# Altium schematic sheet SCH-CAPE-Nucleo_Interface_V1R0.SchDoc(SCH-CAPE-Nucleo_Interface_V1R0) (continued)

## Library data of the parts
C1-C17 C19-C34 = SMT Capacitor: Ceramic Capacitor, =Value, =Tolerance, =VoltageRating, =Package; Component Kind: Standard; Library Name: PEF.IntLib
C18 = SMT Capacitor: Ceramic Capacitor, =Value, =Tolerance, =VoltageRating, =Package; Value: 0.1 µF; Component Kind: Standard; Library Name: PEF.IntLib
D1 = CMP-1055-00395-1: Schottky Power Rectifier, 2-Pin SMC, Tape and Reel; Manufacturer: On Semiconductor; IFSM Max (A): 80; Configuration: Single; RoHS: FALSE; PartNumber: MBRS340T3; Packing: Tape and Reel; PackageVersion: Rev. E, 08/2005; PackageReference: SMC-2-403-03; PackageDescription: 2-Pin Small Molded Package, J-Bend Lead, Body 5.845 x 6.855 mm; Mounting Technology: Surface Mount; Library Name: Altium Content Vault; DatasheetVersion: Rev. 11; ComponentLink3URL: http://www.onsemi.com/pub_link/Collateral/403-03.PDF; ComponentLink3Description: Package Specification; ComponentLink2URL: http://www.onsemi.cn/pub_link/Collateral/MBRS340T3-D.PDF; ComponentLink2Description: Datasheet; ComponentLink1URL: http://www.onsemi.com/; ComponentLink1Description: Manufacturer URL; Component Kind: Standard
DBG1 = Header 16X2H: Header, 16-Pin, Dual row, Right Angle; Signal Integrity: Connector; Library Name: Miscellaneous Connectors.IntLib; LatestRevisionNote: Re-released for DXP Platform.; LatestRevisionDate: 17-Jul-2002; Component Kind: Standard; Publisher: Altium Limited
DS1-DS7 TNC_EGY TNC_PTT UHF VHF = CMP-2000-05792-1: Chip LED Lamp, Green, 5 V, 30 mA, -40 to 85 degC, 2-Pin SMD, RoHS, Tape and Reel; Manufacturer: Kingbright; Wavelength - Peak: 574nm; Wavelength - Dominant: 570nm; Voltage - Forward (Vf) (Typ): 2.1V; Viewing Angle: 50°; Other Names: 754-1152-2; Online Catalog: 3.2mmx1.6mmRoundwithDomedTop1206PackageLEDs; Millicandela Rating: 300mcd; Lens Type: Clear; Lens Style/Size: RoundwithDomedTop,1.6mm; Current - Test: 20mA; Catalog Drawings: APTD3216SeriesTop    APTD3216SeriesSide; 3D Model: APTD3216x.sldprt    APTD3216x.pro    APTD3216x.igs    APTD3216x.stp; Standard Package: 2,000; Size / Dimension: 3.20mmLx1.60mmW; Part Number: APTD3216CGCK; Packaging: Tape&Reel(TR); Package Reference: APTD3216CGCK; Package Description: 2-Pin Surface Mount Device, Body 3.2 x 1.6 mm; Package / Case: 1206(3216Metric); Mounting Type: SurfaceMount; Mounting Technology: SurfaceMount; Manufacturer URL: http://www.kingbright.com/; Library Name: Altium Content Vault; Height: 1.80mm; Family: LEDIndication-Discrete; Datasheet Version: Rev. V.17B, 04/2015; Datasheet URL: https://www.kingbrightusa.com/images/catalog/spec/APTD3216CGCK.pdf; Component Kind: Standard; Color: Green; Category: Optoelectronics
FB1 = CMP-0686-00732-2: Chip Ferrite Bead for Power Lines, 120 Ohm, 3500 mA, -55 to 125 degC, 3.2 x 1.6 x 1.3 mm SMD, Tape and Reel; Manufacturer: Murata; Rated Current: 3500 mA; Impedance (at 100 MHz): 120 Ohm +/- 25%; DC Resistance: 0.02 Ohm Max.; Part Number: BLM31PG121SN1L; Packing: Tape and Reel; PackageReference: BLM31-2; PackageDescription: 2-Pin Chip, Body 3.2 x 1.6 mm; Operating Temperature Range: -55 to 125 degC; Mounting Technology: Surface Mount; Library Name: Altium Content Vault; DatasheetVersion: 08/2013; ComponentLink3URL: http://www.murata.com/products/catalog/pdf/c31e.pdf; ComponentLink3Description: Package Specification; ComponentLink2URL: http://www.murata.com/products/catalog/pdf/c31e.pdf; ComponentLink2Description: Datasheet; ComponentLink1URL: http://www.murata.com/; ComponentLink1Description: Manufacturer URL; Component Kind: Standard
N5 = Header 10: Header, 10-Pin; Signal Integrity: Connector; Library Name: Miscellaneous Connectors.IntLib; LatestRevisionNote: Re-released for DXP Platform.; LatestRevisionDate: 17-Jul-2002; Component Kind: Standard; Publisher: Altium Limited
N6 N9 = Header 8: Header, 8-Pin; Signal Integrity: Connector; Library Name: Miscellaneous Connectors.IntLib; LatestRevisionNote: Re-released for DXP Platform.; LatestRevisionDate: 17-Jul-2002; Component Kind: Standard; Publisher: Altium Limited
N8 = Header 6: Header, 6-Pin; Signal Integrity: Connector; Library Name: Miscellaneous Connectors.IntLib; LatestRevisionNote: Re-released for DXP Platform.; LatestRevisionDate: 17-Jul-2002; Component Kind: Standard; Publisher: Altium Limited
PA_Power TNCi TNCo UHFi UHFo Vbus Vbus_f VHFi VHFo = CMP-1672-00008-1: Test Point, 1 Position SMD, RoHS, Tape and Reel; Manufacturer: Keystone Electronics; RoHSCompliant: True; Pins: 1; Part Number: 5019; Packaging: Tape and Reel; PackageReference: 5019; Package Quantity: 1000; PackageDescription: 1-Pin Surface Mount Device, Body 3.81 x 2.03 mm; Mounting Technology: Surface Mount; Mount: Surface Mount; Library Name: Altium Content Vault; DatasheetVersion: 03/2014; Contact Plating: 9; ComponentLink2URL: http://www.keyelco.com/product-pdf.cfm?p=1357; ComponentLink2Description: Datasheet; ComponentLink1URL: http://www.keyelco.com/; ComponentLink1Description: Manufacturer URL; Component Kind: Standard
R1 R2 R5-R15 R19-R27 R29-R51 = SMT Resistor: Generic SMT Resistor; Component Kind: Standard; Library Name: PEF.IntLib
R3 = SMT Resistor: Generic SMT Resistor; Value: 56; Component Kind: Standard; Library Name: PEF.IntLib
R4 = SMT Resistor: Generic SMT Resistor; Value: 160; Component Kind: Standard; Library Name: PEF.IntLib
R16 R17 = SMT Resistor: Generic SMT Resistor; Value: 330; Component Kind: Standard; Library Name: PEF.IntLib
R18 = SMT Resistor: Generic SMT Resistor; Value: 330; Tolerance: {Tol}; Component Kind: Standard; Library Name: PEF.IntLib
R28 = SMT Resistor: Generic SMT Resistor; Value: 1 KΩ; Component Kind: Standard; Library Name: PEF.IntLib
TNC_PROG = Header 5: Header, 5-Pin; Signal Integrity: Connector; Library Name: Miscellaneous Connectors.IntLib; LatestRevisionNote: Re-released for DXP Platform.; LatestRevisionDate: 17-Jul-2002; Component Kind: Standard; Publisher: Altium Limited
U1 = CMP-0859-00540-3: Dual Bus Buffer Gate with 3-State Outputs, DCT0008A, LARGE T&R; Manufacturer: Texas Instruments; Voltage(Nom)(V): 1.8,2.5,3.3,5; VCC(Min)(V): 1.65; VCC(Max)(V): 5.5; tpd @ Nom Voltage(Max)(ns): 9.1,4.8,4.3,3.7; Technology Family: LVC; Sub-Family: Non-Inverting Buffer/Driver; Schmitt Trigger: No; Rating: Catalog; Output Type: CMOS; Output Drive (IOL/IOH)(Max)(mA): 32/-32; Logic: True; Input Type: CMOS/TTL; ICC @ Nom Voltage(Max)(mA): 0.01; F @ Nom Voltage(Max)(Mhz): 150; Bits(#): 2; 3-State Output: Yes; PartId: 1; PackageReference: DCT0008A; Operating Temperature Range(C): -40 to 125; Library Name: Altium Content Vault; Generic Part Number: SN74LVC2G125; DatasheetVersion: SCES204O; Component Kind: Standard
U2 = CMP-0859-00210-3: Dual Inverter, DBV0006A, SMALL T&R; Manufacturer: Texas Instruments; Voltage(Nom)(V): 1.8,2.5,3.3,5; VCC(Min)(V): 1.65; VCC(Max)(V): 5.5; tpd @ Nom Voltage(Max)(ns): 8,4.9,4.6,3.7; Technology Family: LVC; Sub-Family: Inverting Buffer/Driver; Schmitt Trigger: No; Rating: Catalog; Output Type: CMOS; Output Drive (IOL/IOH)(Max)(mA): 32/-32; Logic: Inverting; Input Type: CMOS/TTL; ICC @ Nom Voltage(Max)(mA): 0.01; F @ Nom Voltage(Max)(Mhz): 150; Bits(#): 2; PartId: 1; PackageReference: DBV0006A; Operating Temperature Range(C): -40 to 125; Library Name: Altium Content Vault; Generic Part Number: SN74LVC2G04; DatasheetVersion: SCES195M; Component Kind: Standard; 3-State Output: No
U3 = CMP-0314-00167-2: Remote 8-Bit I2C and SMBus I/O Expander with Interrupt Output and Configuration Registers, 8 Outputs, 2.3 to 5.5 V, -40 to 85 degC, 16-pin SOIC (DW), Green (RoHS & no Sb/Br); Manufacturer: Texas Instruments; VCC(Min)(V): 2.3; VCC(Max)(V): 5.5; Technology Family: PCA; SubFamily: I2C IO Expander; Push-Pull I/O Type: Yes; No. of Outputs: 8; Max Frequency(kHz): 400; I2C Address: 0100 xxx; Vcc range(V): 2.3 to 5.5; PartNumber: PCA9554DWR; PackageVersion: revG, Jan-2011; PackageReference: DW0016A; PackageDescription: 16-Pin Small Outline Integrated Circuit, 10.3 x 7.5 mm, Pitch 1.27 mm Pitch; Mounting Technology: Surface Mount; Library Name: Altium Content Vault; Interrupt Output: Yes; fSCLK(Max)(MHz): 400; Eco-Plan: Green (RoHS & no Sb/Br); DatasheetVersion: SCPS128B; Configuration Registers: Yes; ComponentLink2URL: http://www.ti.com/litv/pdf/msoi003g; ComponentLink2Description: Package Specification; ComponentLink1URL: http://www.ti.com/general/docs/lit/getliterature.tsp?genericPartNumber=PCA9554&fileType=pdf; ComponentLink1Description: Datasheet; Component Kind: Standard; Code_JEDEC: MS-013-AA; 5V Tolerant I/O: Yes; # of I/Os: 8
U4 = CMP-2000-06185-1: Single-Channel 1-Wire Master, 2.9 to 5.5 V, -40 to 85 degC, 8-Pin SO, RoHS, Rail/Tube; Manufacturer: Maxim; Voltage - Supply: 3.3V,5V; Protocol: 1-Wire®; Product Training Modules: Lead(SnPb)FinishforCOTS    Long-TermSupplyProgram; Interface: I²C; Function: Bridge,1-Wire®toI²C; Dynamic Catalog: I²CControllers; Current - Supply: 750µA; Supplier Device Package: 8-SOIC; Standards: -; Standard Package: 100; Series: -; Packaging: Tube; Package URL: http://pdfserv.maximintegrated.com/package_dwgs/21-0041.PDF; Package Reference: SO-8; Package Description: 8-Pin Small Outline Integrated Circuit, Body 5 x 4 mm, Pitch 1.27 mm; Package / Case: 8-SOIC(0.154",3.90mmWidth); Operating Temperature: -40°C~85°C; Mounting Technology: SurfaceMount; Manufacturer URL: http://www.maximintegrated.com/; Library Name: Altium Content Vault; Family: Interface-Controllers; Datasheet Version: Rev. 10, 01/2015; Datasheet URL: http://www.mouser.com/ds/2/256/DS2482-100-39831.pdf; Component Kind: Standard; Category: IntegratedCircuits(ICs)
U5 = MX614: Manufacturer: CML Microcircuits; Part Number: MX614TN; Component Kind: Standard; Library Name: PEF.IntLib
U6 = CMP-0115-02811-1: Flash Microcontroller with XLP Technology, 32 MHz, 15 I/O, -40 to 85 degC, 20-pin SSOP (SS20), Tube; Manufacturer: Microchip; Timers: 4 - 8-bit, 1 - 16-bit; RAM: 1024; Program Memory KWords: 8; Program Memory KBytes: 14; Operation Voltage Range: 1.8V - 3.6V; Max. CPU Speed MHz: 32; Internal Oscillator: 32 MHz, 31 kHz; I/O Pins: 15; EEPROM Data Memory (bytes): 256; Digital Communication: 1 -A/E/USART, 2 -MSSP(SPI/I2C); # of A/D Channels: 12; PartNumber: PIC16LF1847-I/SS; Packing: TUBE; PackageVersion: C04-072B, 12/2012; PackageReference: SSOP-SS20; PackageDescription: 20-Lead Plastic Shrink Small Outline, 5.3 x 7.2 mm Body, 0.65 mm Pitch; Mounting Technology: Surface Mount; Memory Type: Flash; Library Name: Altium Content Vault; DatasheetVersion: Rev. D; ComponentLink3URL: Product URL:http://www.microchip.com/datasheet/PIC16F1847; ComponentLink3Description: URL Link1; ComponentLink2URL: http://www.microchip.com/stellent/groups/techpub_sg/documents/packagingspec/en012702.pdf; ComponentLink2Description: Package Specification; ComponentLink1URL: http://ww1.microchip.com/downloads/en/DeviceDoc/40001453D.pdf; ComponentLink1Description: Datasheet; Component Kind: Standard
U7 = CMP-0187-00277-2: Rail-to-Rail Input/Output, 10 MHz Operational Amplifier, 8-Pin SOIC, Industrial Temperature; RevisionNote: URLs added.; PackageVersion: revBB, Aug-2009; PackageReference: SOIC-SN8; PackageDescription: 8-Lead Plastic Small Outline (SN) - Narrow, 3.90mm Body [SOIC]; Library Name: Altium Content Vault; DatasheetVersion: revD, Feb-2009; ComponentLink3URL: http://www.microchip.com/stellent/groups/techpub_sg/documents/packagingspec/en012702.pdf; ComponentLink3Description: Package Specification; ComponentLink2URL: http://ww1.microchip.com/downloads/en/DeviceDoc/21685d.pdf; ComponentLink2Description: Datasheet; ComponentLink1URL: http://www.microchip.com/; ComponentLink1Description: Manufacturer URL; Component Kind: Standard; Code_JEDEC: MS-012; Code_IPC: SOIC127P600-8
U8 = CMP-0163-00024-1: 64K, 8192x8-bit, 3.6V SPI Serial SRAM, 8-Pin TSSOP, Industrial Temperature; PackageVersion: revBB, Aug-2009; PackageReference: TSSOP-ST8; PackageDescription: 8-Lead Plastic Thin Shrink Small Outline (ST) - 4.4mm Body [TSSOP]; Library Name: Altium Content Vault; DatasheetVersion: revC, Apr-2009; Component Kind: Standard; Code_JEDEC: MO-153
U9 = CMP-0788-00011-1: I2C(R) CMOS 8 x 8 Unbuffered Analog Switch Array with Dual / Single Supplies, +/-5 V Supply, 32-pin QFN (CP-32-2), Reel; Manufacturer: Analog Devices; Small Signal BW (MHz): 300; Output Buffer: No; Supply Voltage (V): +/-5; PartNumber: ADG2188BCPZ-REEL7; Packing: Reel; PackageVersion: Jan-05; PackageReference: CP-32-2; PackageDescription: 32-Pin Lead Frame Chip Scale Package, Body 5 x 5 mm, Pitch 0.5 mm; Mounting Technology: Surface Mount; Library Name: Altium Content Vault; DatasheetVersion: Rev. 0; Control Interface: I2C; ComponentLink3URL: http://www.analog.com/static/imported-files/packages/414143737956480539664569CP_32_2.pdf; ComponentLink3Description: Package Specification; ComponentLink2URL: http://www.analog.com/static/imported-files/data_sheets/ADG2188.pdf; ComponentLink2Description: Datasheet; ComponentLink1URL: http://www.analog.com/en/index.html; ComponentLink1Description: Manufacturer URL; Component Kind: Standard; Code_JEDEC: MO-220-VHHD-2
UHFC VHFC = Header 9X2: Header, 9-Pin, Dual row; Signal Integrity: Connector; Library Name: Miscellaneous Connectors.IntLib; LatestRevisionNote: Re-released for DXP Platform.; LatestRevisionDate: 17-Jul-2002; Component Kind: Standard; Publisher: Altium Limited
USB1 = CMP-2000-07498-1: USB-B Receptacle Connector, Height 10.87 mm, 0 to 50 degC, RoHS, Tray; Part Number: 1-1734346-1; Manufacturer: TE Connectivity; Plating: Nickel; Number of Positions: 4; Number of Ports: 1; Insulation Resistance: 1GR; Case\/Package: SMD/SMT; Termination: SMD/SMT; RoHSCompliant: true; REACH SVHC: Unknown; Packaging: Tray; Package Reference: 1734346-1; Package Quantity: 100; Package Description: 4-Pin Pin Surface Mount Device, Body 12.04 x 16 mm, Height 10.87 mm; Orientation: RightAngle; Number of Terminals: 4; Number of Contacts: 4; Mounting Technology: SurfaceMount; Mount: Horizontal; Material: Bronze; Manufacturer URL: http://www.te.com/; Library Name: Altium Content Vault; Length: 16.5mm; Insulation Material: Thermoplastic; Housing Material: Nylon; Housing Colour: Black; Gender: Receptacle; Flammability Rating: UL94V-0; ELV: Compliant; Depth: 16mm; Datasheet Version: Rev. C, 06/2011; Datasheet URL: http://www.te.com/commerce/DocumentDelivery/DDEController?Action=srchrtrv&DocNm=1734346&DocType=Customer+Drawing&DocLang=English; Contact Plating: Gold; Contact Material: Bronze; Connector Type: Receptacle; Component Kind: Standard
Y1 = CMP-1688-00002-1: Ceramic SMD Crystal, 8 MHz, +/- 20 ppm, 200 Ohm, -20 to 70 degC, 4-Pin SMD, RoHS, Tape and Reel; Manufacturer: Abracon; Switching Frequency: 30 KHz; Output Voltage: 3.3 V; Outputs: 4; Output Current: 1.8 A; Min Supply Voltage: 8.2 V; Max Supply Voltage: 45 V; Width: 4.5 mm; Weight: 0.004233 oz; RoHSCompliant: true; REACH SVHC: No SVHC; Radiation Hardening: No; Pins: 28; Part Number: ABM3B-20.000MHZ-B2-T; Packaging: Rail/Tube; PackageReference: ABM3B; Package Quantity: 50; PackageDescription: 4-Pin Surface Mount Device, Body 5 x 3.2 mm; Mount: Surface Mount; Min Operating Temperature: -40 degC; Max Operating Temperature: 85 degC; Library Name: Altium Content Vault; Length: 9.8 mm; Height: 1.05 mm; DatasheetVersion: Aug-15; ComponentLink2URL: http://www.abracon.com/Resonators/abm3b.pdf; ComponentLink2Description: Datasheet; ComponentLink1URL: http://www.abracon.com/; ComponentLink1Description: Manufacturer URL; Component Kind: Standard
Y2 = CMP-1669-00002-2: CRYSTAL 8.00 MHZ 20PF 49US; Manufacturer: ECS; Part Number: ECS-035-18-4X-DU; PackageReference: 4X-3IL; Library Name: Altium Content Vault; ComponentLink1URL: http://www.ecsxtal.com/store/pdf/hc-49usx.pdf; ComponentLink1Description: Datasheet; Component Kind: Standard
